# Altium schematic sheet Cray1-2.SchDoc
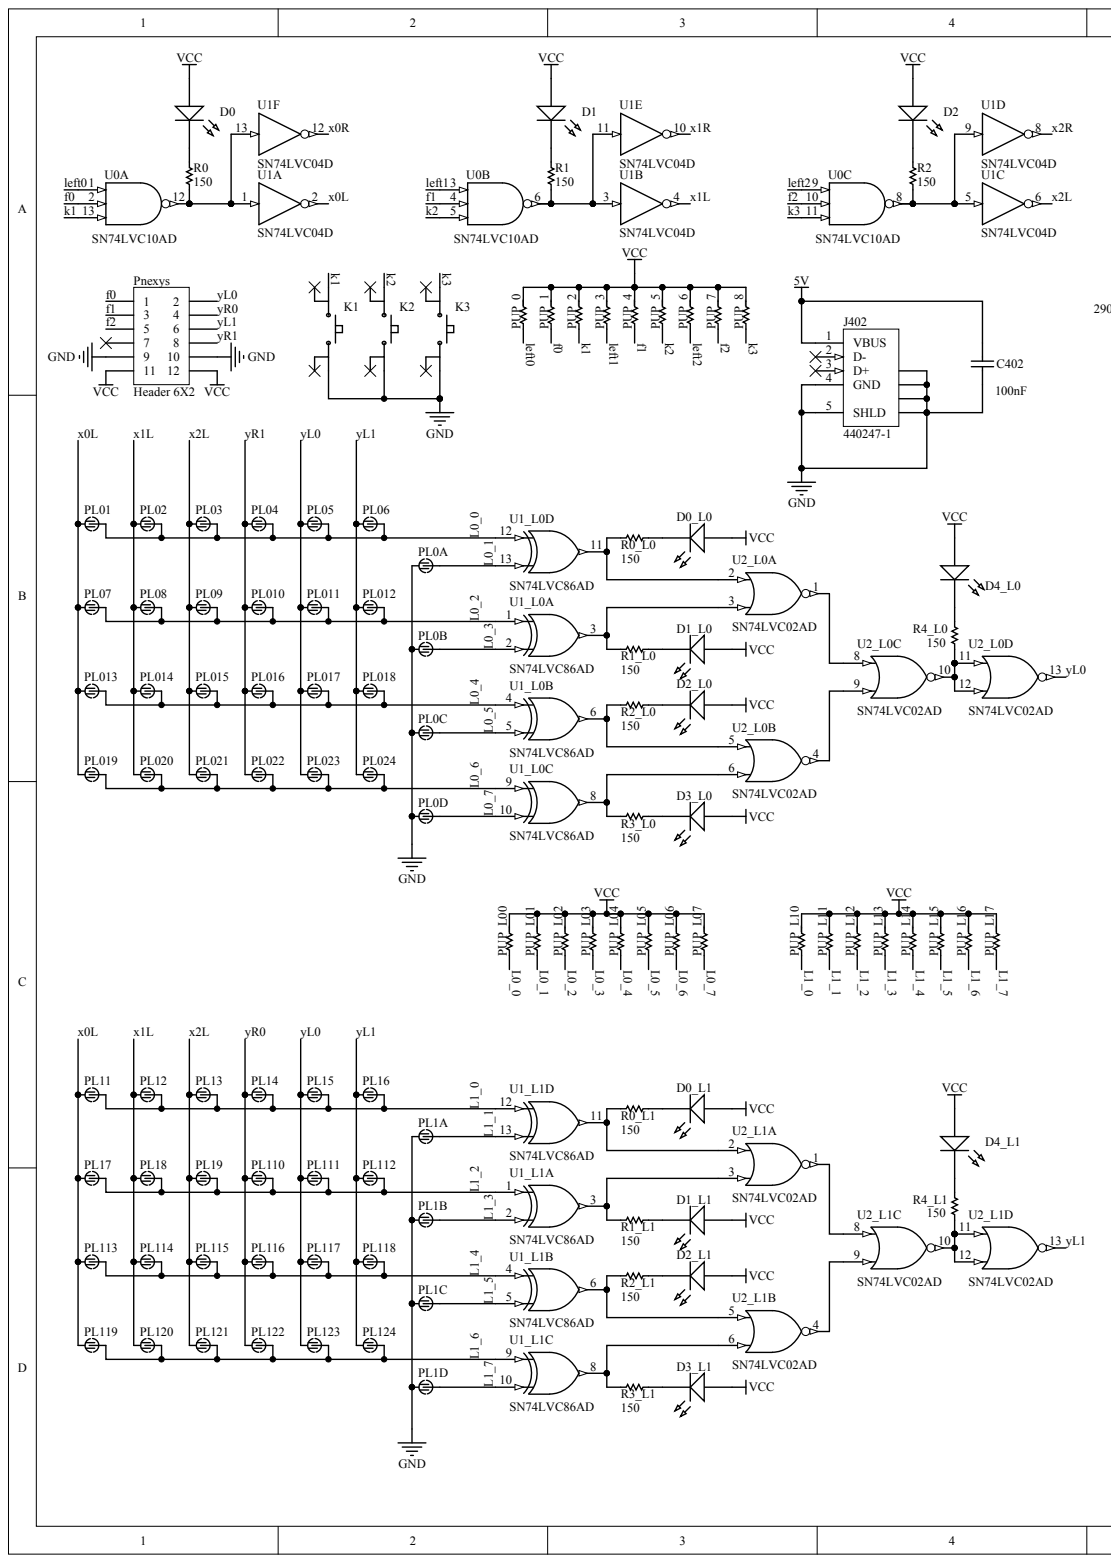
[diagram p0: Cray1-2.SchDoc, left]
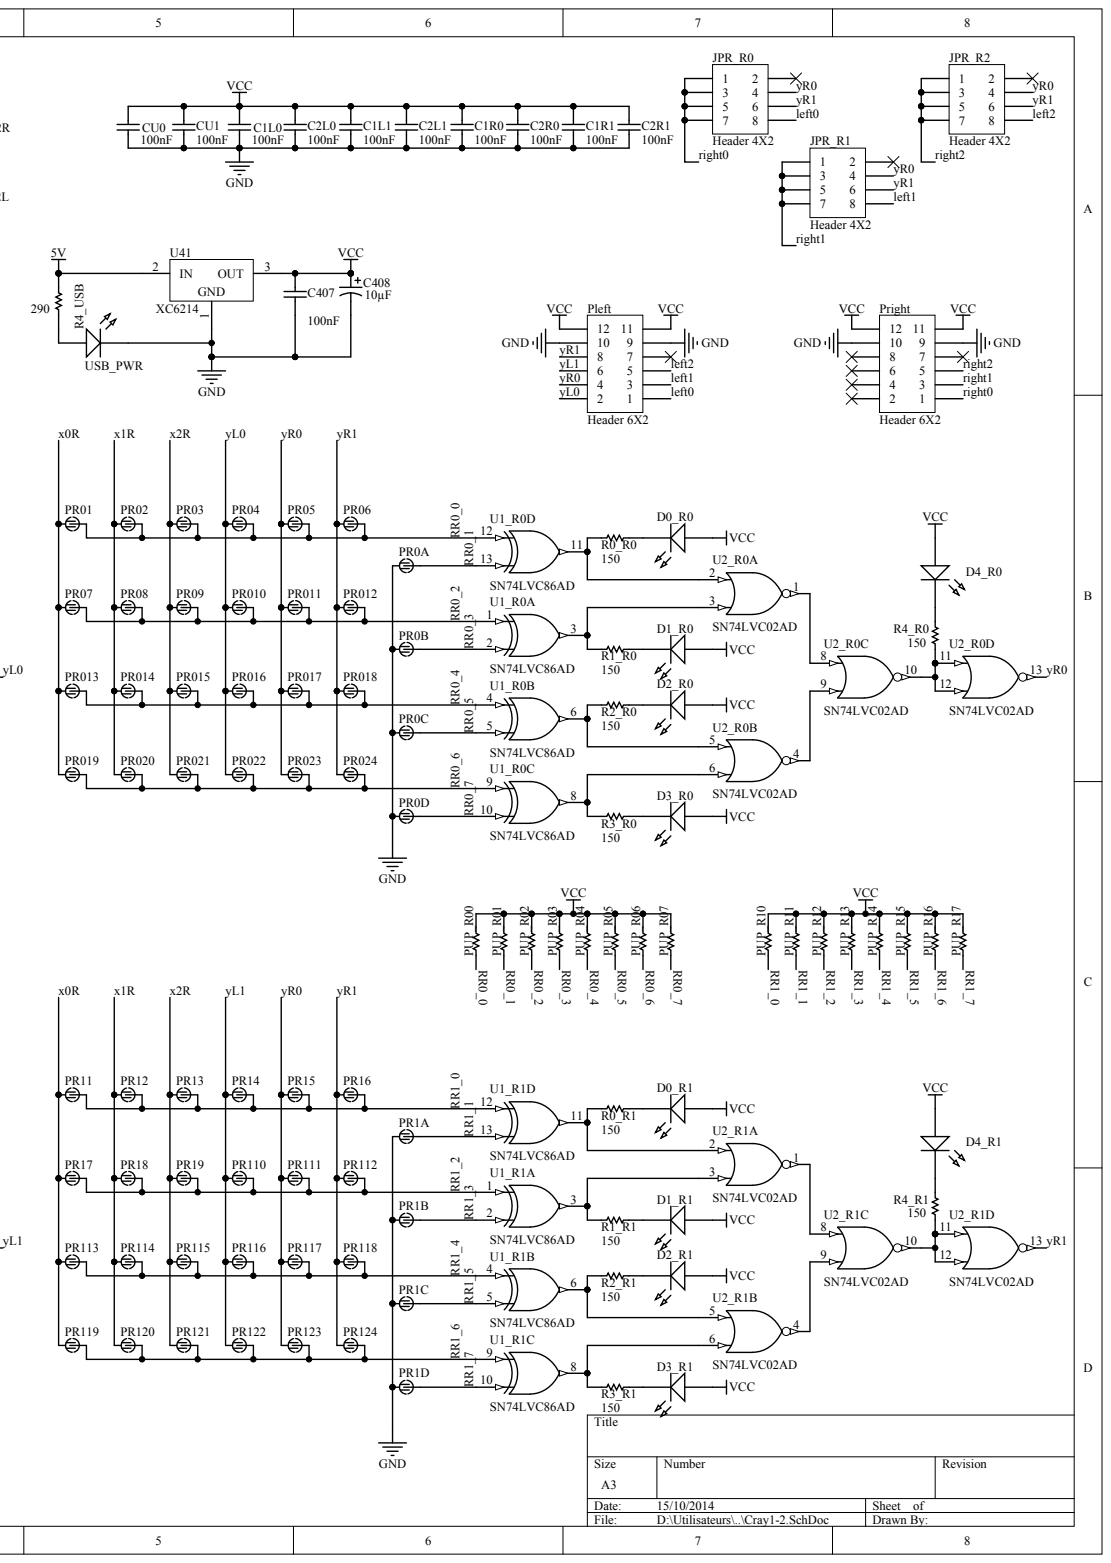
[diagram p1: Cray1-2.SchDoc, right]

## Parts
C1L0  value 100nF  (hidden comment: Cap Semi)
C1L1  value 100nF  (hidden comment: Cap Semi)
C1R0  value 100nF  (hidden comment: Cap Semi)
C1R1  value 100nF  (hidden comment: Cap Semi)
C2L0  value 100nF  (hidden comment: Cap Semi)
C2L1  value 100nF  (hidden comment: Cap Semi)
C2R0  value 100nF  (hidden comment: Cap Semi)
C2R1  value 100nF  (hidden comment: Cap Semi)
C402  value 100nF  (hidden comment: Cap Semi)
C407  value 100nF  (hidden comment: Cap Semi)
C408  value 10µF  (hidden comment: Cap Pol3)
CU0  value 100nF  (hidden comment: Cap Semi)
CU1  value 100nF  (hidden comment: Cap Semi)
D0  Led  (value not drawn)
D0_L0  Led  (value not drawn)
D0_L1  Led  (value not drawn)
D0_R0  Led  (value not drawn)
D0_R1  Led  (value not drawn)
D1  Led  (value not drawn)
D1_L0  Led  (value not drawn)
D1_L1  Led  (value not drawn)
D1_R0  Led  (value not drawn)
D1_R1  Led  (value not drawn)
D2  Led  (value not drawn)
D2_L0  Led  (value not drawn)
D2_L1  Led  (value not drawn)
D2_R0  Led  (value not drawn)
D2_R1  Led  (value not drawn)
D3_L0  Led  (value not drawn)
D3_L1  Led  (value not drawn)
D3_R0  Led  (value not drawn)
D3_R1  Led  (value not drawn)
D4_L0  Led  (value not drawn)
D4_L1  Led  (value not drawn)
D4_R0  Led  (value not drawn)
D4_R1  Led  (value not drawn)
J402  440247-1
JPR_R0  Header 4X2
JPR_R1  Header 4X2
JPR_R2  Header 4X2
K1  SPST MicroSwitch  (value not drawn)
K2  SPST MicroSwitch  (value not drawn)
K3  SPST MicroSwitch  (value not drawn)
PL0A  (no value)
PL0B  (no value)
PL0C  (no value)
PL0D  (no value)
PL01  (no value)
PL1A  (no value)
PL1B  (no value)
PL1C  (no value)
PL1D  (no value)
PL02  (no value)
PL03  (no value)
PL04  (no value)
PL05  (no value)
PL06  (no value)
PL07  (no value)
PL08  (no value)
PL09  (no value)
PL010  (no value)
PL011  (no value)
PL11  (no value)
PL012  (no value)
PL12  (no value)
PL013  (no value)
PL13  (no value)
PL014  (no value)
PL14  (no value)
PL015  (no value)
PL15  (no value)
PL016  (no value)
PL16  (no value)
PL017  (no value)
PL17  (no value)
PL018  (no value)
PL18  (no value)
PL019  (no value)
PL19  (no value)
PL020  (no value)
PL021  (no value)
PL022  (no value)
PL023  (no value)
PL024  (no value)
PL110  (no value)
PL111  (no value)
PL112  (no value)
PL113  (no value)
PL114  (no value)
PL115  (no value)
PL116  (no value)
PL117  (no value)
PL118  (no value)
PL119  (no value)
PL120  (no value)
PL121  (no value)
PL122  (no value)
PL123  (no value)
PL124  (no value)
Pleft  Header 6X2
Pnexys  Header 6X2
PR0A  (no value)
PR0B  (no value)
PR0C  (no value)
PR0D  (no value)
PR01  (no value)
PR1A  (no value)
PR1B  (no value)
PR1C  (no value)
PR1D  (no value)
PR02  (no value)
PR03  (no value)
PR04  (no value)
PR05  (no value)
PR06  (no value)
PR07  (no value)
PR08  (no value)
PR09  (no value)
PR010  (no value)
PR011  (no value)
PR11  (no value)
PR012  (no value)
PR12  (no value)
PR013  (no value)
PR13  (no value)
PR014  (no value)
PR14  (no value)
PR015  (no value)
PR15  (no value)
PR016  (no value)
PR16  (no value)
PR017  (no value)
PR17  (no value)
PR018  (no value)
PR18  (no value)
PR019  (no value)
PR19  (no value)
PR020  (no value)
PR021  (no value)
PR022  (no value)
PR023  (no value)
PR024  (no value)
PR110  (no value)
PR111  (no value)
PR112  (no value)
PR113  (no value)
PR114  (no value)
PR115  (no value)
PR116  (no value)
PR117  (no value)
PR118  (no value)
PR119  (no value)
PR120  (no value)
PR121  (no value)
PR122  (no value)
PR123  (no value)
PR124  (no value)
Pright  Header 6X2
PUP_0  Res Semi  (value not drawn)
PUP_1  Res Semi  (value not drawn)
PUP_2  Res Semi  (value not drawn)
PUP_3  Res Semi  (value not drawn)
PUP_4  Res Semi  (value not drawn)
PUP_5  Res Semi  (value not drawn)
PUP_6  Res Semi  (value not drawn)
PUP_7  Res Semi  (value not drawn)
PUP_8  Res Semi  (value not drawn)
PUP_L00  Res Semi  (value not drawn)
PUP_L01  Res Semi  (value not drawn)
PUP_L02  Res Semi  (value not drawn)
PUP_L03  Res Semi  (value not drawn)
PUP_L04  Res Semi  (value not drawn)
PUP_L05  Res Semi  (value not drawn)
PUP_L06  Res Semi  (value not drawn)
PUP_L07  Res Semi  (value not drawn)
PUP_L10  Res Semi  (value not drawn)
PUP_L11  Res Semi  (value not drawn)
PUP_L12  Res Semi  (value not drawn)
PUP_L13  Res Semi  (value not drawn)
PUP_L14  Res Semi  (value not drawn)
PUP_L15  Res Semi  (value not drawn)
PUP_L16  Res Semi  (value not drawn)
PUP_L17  Res Semi  (value not drawn)
PUP_R00  Res Semi  (value not drawn)
PUP_R01  Res Semi  (value not drawn)
PUP_R02  Res Semi  (value not drawn)
PUP_R03  Res Semi  (value not drawn)
PUP_R04  Res Semi  (value not drawn)
PUP_R05  Res Semi  (value not drawn)
PUP_R06  Res Semi  (value not drawn)
PUP_R07  Res Semi  (value not drawn)
PUP_R10  Res Semi  (value not drawn)
PUP_R11  Res Semi  (value not drawn)
PUP_R12  Res Semi  (value not drawn)
PUP_R13  Res Semi  (value not drawn)
PUP_R14  Res Semi  (value not drawn)
PUP_R15  Res Semi  (value not drawn)
PUP_R16  Res Semi  (value not drawn)
PUP_R17  Res Semi  (value not drawn)
R0  value 150  (hidden comment: Res Semi)
R0_L0  value 150  (hidden comment: Res Semi)
R0_L1  value 150  (hidden comment: Res Semi)
R0_R0  value 150  (hidden comment: Res Semi)
R0_R1  value 150  (hidden comment: Res Semi)
R1  value 150  (hidden comment: Res Semi)
R1_L0  value 150  (hidden comment: Res Semi)
R1_L1  value 150  (hidden comment: Res Semi)
R1_R0  value 150  (hidden comment: Res Semi)
R1_R1  value 150  (hidden comment: Res Semi)
R2  value 150  (hidden comment: Res Semi)
R2_L0  value 150  (hidden comment: Res Semi)
R2_L1  value 150  (hidden comment: Res Semi)
R2_R0  value 150  (hidden comment: Res Semi)
R2_R1  value 150  (hidden comment: Res Semi)
R3_L0  value 150  (hidden comment: Res Semi)
R3_L1  value 150  (hidden comment: Res Semi)
R3_R0  value 150  (hidden comment: Res Semi)
R3_R1  value 150  (hidden comment: Res Semi)
R4_L0  value 150  (hidden comment: Res Semi)
R4_L1  value 150  (hidden comment: Res Semi)
R4_R0  value 150  (hidden comment: Res Semi)
R4_R1  value 150  (hidden comment: Res Semi)
R4_USB  value 290  (hidden comment: Res Semi)
U0  SN74LVC10AD  (parts A,B,C)
U1  SN74LVC04D  (parts A,B,C,D,E,F)
U1_L0  SN74LVC86AD  (parts A,B,C,D)
U1_L1  SN74LVC86AD  (parts A,B,C,D)
U1_R0  SN74LVC86AD  (parts A,B,C,D)
U1_R1  SN74LVC86AD  (parts A,B,C,D)
U2_L0  SN74LVC02AD  (parts A,B,C,D)
U2_L1  SN74LVC02AD  (parts A,B,C,D)
U2_R0  SN74LVC02AD  (parts A,B,C,D)
U2_R1  SN74LVC02AD  (parts A,B,C,D)
U41  XC6214
USB_PWR  Led  (value not drawn)

## Nets
5V: C402.2 J402.1(VBUS) R4_USB.1 U41.2
GND: C1L0.1 C1L1.1 C1R0.1 C1R1.1 C2L0.1 C2L1.1 C2R0.1 C2R1.1 C402.1 C407.1 C408.2 CU0.1 CU1.1 J402.4(GND) J402.5(SHLD) J402.6 J402.7 J402.8 J402.9 K1.3 K2.3 K3.3 PL0A.1 PL0B.1 PL0C.1 PL0D.1 PL1A.1 PL1B.1 PL1C.1 PL1D.1 Pleft.9 Pleft.10 Pnexys.9 Pnexys.10 PR0A.1 PR0B.1 PR0C.1 PR0D.1 PR1A.1 PR1B.1 PR1C.1 PR1D.1 Pright.9 Pright.10 U0.7 U1.7 U1_L0.7 U1_L1.7 U1_R0.7 U1_R1.7 U2_L0.7 U2_L1.7 U2_R0.7 U2_R1.7 U41.1 USB_PWR.K
VCC: C1L0.2 C1L1.2 C1R0.2 C1R1.2 C2L0.2 C2L1.2 C2R0.2 C2R1.2 C407.2 C408.1 CU0.2 CU1.2 D0.A D0_L0.A D0_L1.A D0_R0.A D0_R1.A D1.A D1_L0.A D1_L1.A D1_R0.A D1_R1.A D2.A D2_L0.A D2_L1.A D2_R0.A D2_R1.A D3_L0.A D3_L1.A D3_R0.A D3_R1.A D4_L0.A D4_L1.A D4_R0.A D4_R1.A Pleft.11 Pleft.12 Pnexys.11 Pnexys.12 Pright.11 Pright.12 PUP_0.1 PUP_1.1 PUP_2.1 PUP_3.1 PUP_4.1 PUP_5.1 PUP_6.1 PUP_7.1 PUP_8.1 PUP_L00.1 PUP_L01.1 PUP_L02.1 PUP_L03.1 PUP_L04.1 PUP_L05.1 PUP_L06.1 PUP_L07.1 PUP_L10.1 PUP_L11.1 PUP_L12.1 PUP_L13.1 PUP_L14.1 PUP_L15.1 PUP_L16.1 PUP_L17.1 PUP_R00.1 PUP_R01.1 PUP_R02.1 PUP_R03.1 PUP_R04.1 PUP_R05.1 PUP_R06.1 PUP_R07.1 PUP_R10.1 PUP_R11.1 PUP_R12.1 PUP_R13.1 PUP_R14.1 PUP_R15.1 PUP_R16.1 PUP_R17.1 U0.14 U1.14 U1_L0.14 U1_L1.14 U1_R0.14 U1_R1.14 U2_L0.14 U2_L1.14 U2_R0.14 U2_R1.14 U41.3
F0: Pnexys.1 PUP_1.2 U0.2
F1: Pnexys.3 PUP_4.2 U0.4
F2: Pnexys.5 PUP_7.2 U0.10
K1: K1.1 PUP_2.2 U0.13
K2: K2.1 PUP_5.2 U0.5
K3: K3.1 PUP_8.2 U0.11
L0_0: PL01.0 PL02.0 PL03.0 PL04.0 PL05.0 PL06.0 PUP_L00.2 U1_L0.12
L0_1: PL0A.0 PUP_L01.2 U1_L0.13
L0_2: PL07.0 PL08.0 PL09.0 PL010.0 PL011.0 PL012.0 PUP_L02.2 U1_L0.1
L0_3: PL0B.0 PUP_L03.2 U1_L0.2
L0_4: PL013.0 PL014.0 PL015.0 PL016.0 PL017.0 PL018.0 PUP_L04.2 U1_L0.4
L0_5: PL0C.0 PUP_L05.2 U1_L0.5
L0_6: PL019.0 PL020.0 PL021.0 PL022.0 PL023.0 PL024.0 PUP_L06.2 U1_L0.9
L0_7: PL0D.0 PUP_L07.2 U1_L0.10
L1_0: PL11.0 PL12.0 PL13.0 PL14.0 PL15.0 PL16.0 PUP_L10.2 U1_L1.12
L1_1: PL1A.0 PUP_L11.2 U1_L1.13
L1_2: PL17.0 PL18.0 PL19.0 PL110.0 PL111.0 PL112.0 PUP_L12.2 U1_L1.1
L1_3: PL1B.0 PUP_L13.2 U1_L1.2
L1_4: PL113.0 PL114.0 PL115.0 PL116.0 PL117.0 PL118.0 PUP_L14.2 U1_L1.4
L1_5: PL1C.0 PUP_L15.2 U1_L1.5
L1_6: PL119.0 PL120.0 PL121.0 PL122.0 PL123.0 PL124.0 PUP_L16.2 U1_L1.9
L1_7: PL1D.0 PUP_L17.2 U1_L1.10
LEFT0: JPR_R0.8 Pleft.1 PUP_0.2 U0.1
LEFT1: JPR_R1.8 Pleft.3 PUP_3.2 U0.3
LEFT2: JPR_R2.8 Pleft.5 PUP_6.2 U0.9
RIGHT0: JPR_R0.1 JPR_R0.3 JPR_R0.5 JPR_R0.7 Pright.1
RIGHT1: JPR_R1.1 JPR_R1.3 JPR_R1.5 JPR_R1.7 Pright.3
RIGHT2: JPR_R2.1 JPR_R2.3 JPR_R2.5 JPR_R2.7 Pright.5
RR0_0: PR01.0 PR02.0 PR03.0 PR04.0 PR05.0 PR06.0 PUP_R00.2 U1_R0.12
RR0_1: PR0A.0 PUP_R01.2 U1_R0.13
RR0_2: PR07.0 PR08.0 PR09.0 PR010.0 PR011.0 PR012.0 PUP_R02.2 U1_R0.1
RR0_3: PR0B.0 PUP_R03.2 U1_R0.2
RR0_4: PR013.0 PR014.0 PR015.0 PR016.0 PR017.0 PR018.0 PUP_R04.2 U1_R0.4
RR0_5: PR0C.0 PUP_R05.2 U1_R0.5
RR0_6: PR019.0 PR020.0 PR021.0 PR022.0 PR023.0 PR024.0 PUP_R06.2 U1_R0.9
RR0_7: PR0D.0 PUP_R07.2 U1_R0.10
RR1_0: PR11.0 PR12.0 PR13.0 PR14.0 PR15.0 PR16.0 PUP_R10.2 U1_R1.12
RR1_1: PR1A.0 PUP_R11.2 U1_R1.13
RR1_2: PR17.0 PR18.0 PR19.0 PR110.0 PR111.0 PR112.0 PUP_R12.2 U1_R1.1
RR1_3: PR1B.0 PUP_R13.2 U1_R1.2
RR1_4: PR113.0 PR114.0 PR115.0 PR116.0 PR117.0 PR118.0 PUP_R14.2 U1_R1.4
RR1_5: PR1C.0 PUP_R15.2 U1_R1.5
RR1_6: PR119.0 PR120.0 PR121.0 PR122.0 PR123.0 PR124.0 PUP_R16.2 U1_R1.9
RR1_7: PR1D.0 PUP_R17.2 U1_R1.10
X0L: PL01.1 PL07.1 PL11.1 PL013.1 PL17.1 PL019.1 PL113.1 PL119.1 U1.2
X0R: PR01.1 PR07.1 PR11.1 PR013.1 PR17.1 PR019.1 PR113.1 PR119.1 U1.12
X1L: PL02.1 PL08.1 PL12.1 PL014.1 PL18.1 PL020.1 PL114.1 PL120.1 U1.4
X1R: PR02.1 PR08.1 PR12.1 PR014.1 PR18.1 PR020.1 PR114.1 PR120.1 U1.10
X2L: PL03.1 PL09.1 PL13.1 PL015.1 PL19.1 PL021.1 PL115.1 PL121.1 U1.6
X2R: PR03.1 PR09.1 PR13.1 PR015.1 PR19.1 PR021.1 PR115.1 PR121.1 U1.8
YL0: PL05.1 PL011.1 PL15.1 PL017.1 PL023.1 PL111.1 PL117.1 PL123.1 Pleft.2 Pnexys.2 PR04.1 PR010.1 PR016.1 PR022.1 U2_L0.13
YL1: PL06.1 PL012.1 PL16.1 PL018.1 PL024.1 PL112.1 PL118.1 PL124.1 Pleft.6 Pnexys.6 PR14.1 PR110.1 PR116.1 PR122.1 U2_L1.13
YR0: JPR_R0.4 JPR_R1.4 JPR_R2.4 PL14.1 PL110.1 PL116.1 PL122.1 Pleft.4 Pnexys.4 PR05.1 PR011.1 PR15.1 PR017.1 PR023.1 PR111.1 PR117.1 PR123.1 U2_R0.13
YR1: JPR_R0.6 JPR_R1.6 JPR_R2.6 PL04.1 PL010.1 PL016.1 PL022.1 Pleft.8 Pnexys.8 PR06.1 PR012.1 PR16.1 PR018.1 PR024.1 PR112.1 PR118.1 PR124.1 U2_R1.13
NetD0_K: D0.K R0.1
NetD0_L0_K: D0_L0.K R0_L0.1
NetD0_L1_K: D0_L1.K R0_L1.1
NetD0_R0_K: D0_R0.K R0_R0.1
NetD0_R1_K: D0_R1.K R0_R1.1
NetD1_K: D1.K R1.1
NetD1_L0_K: D1_L0.K R1_L0.1
NetD1_L1_K: D1_L1.K R1_L1.1
NetD1_R0_K: D1_R0.K R1_R0.1
NetD1_R1_K: D1_R1.K R1_R1.1
NetD2_K: D2.K R2.1
NetD2_L0_K: D2_L0.K R2_L0.1
NetD2_L1_K: D2_L1.K R2_L1.1
NetD2_R0_K: D2_R0.K R2_R0.1
NetD2_R1_K: D2_R1.K R2_R1.1
NetD3_L0_K: D3_L0.K R3_L0.1
NetD3_L1_K: D3_L1.K R3_L1.1
NetD3_R0_K: D3_R0.K R3_R0.1
NetD3_R1_K: D3_R1.K R3_R1.1
NetD4_L0_K: D4_L0.K R4_L0.1
NetD4_L1_K: D4_L1.K R4_L1.1
NetD4_R0_K: D4_R0.K R4_R0.1
NetD4_R1_K: D4_R1.K R4_R1.1
NetR0_2: R0.2 U0.12 U1.1 U1.13
NetR0_L0_2: R0_L0.2 U1_L0.11 U2_L0.2
NetR0_L1_2: R0_L1.2 U1_L1.11 U2_L1.2
NetR0_R0_2: R0_R0.2 U1_R0.11 U2_R0.2
NetR0_R1_2: R0_R1.2 U1_R1.11 U2_R1.2
NetR1_2: R1.2 U0.6 U1.3 U1.11
NetR1_L0_2: R1_L0.2 U1_L0.3 U2_L0.3
NetR1_L1_2: R1_L1.2 U1_L1.3 U2_L1.3
NetR1_R0_2: R1_R0.2 U1_R0.3 U2_R0.3
NetR1_R1_2: R1_R1.2 U1_R1.3 U2_R1.3
NetR2_2: R2.2 U0.8 U1.5 U1.9
NetR2_L0_2: R2_L0.2 U1_L0.6 U2_L0.5
NetR2_L1_2: R2_L1.2 U1_L1.6 U2_L1.5
NetR2_R0_2: R2_R0.2 U1_R0.6 U2_R0.5
NetR2_R1_2: R2_R1.2 U1_R1.6 U2_R1.5
NetR3_L0_2: R3_L0.2 U1_L0.8 U2_L0.6
NetR3_L1_2: R3_L1.2 U1_L1.8 U2_L1.6
NetR3_R0_2: R3_R0.2 U1_R0.8 U2_R0.6
NetR3_R1_2: R3_R1.2 U1_R1.8 U2_R1.6
NetR4_L0_2: R4_L0.2 U2_L0.10 U2_L0.11 U2_L0.12
NetR4_L1_2: R4_L1.2 U2_L1.10 U2_L1.11 U2_L1.12
NetR4_R0_2: R4_R0.2 U2_R0.10 U2_R0.11 U2_R0.12
NetR4_R1_2: R4_R1.2 U2_R1.10 U2_R1.11 U2_R1.12
NetR4_USB_2: R4_USB.2 USB_PWR.A
NetU2_L0_1: U2_L0.1 U2_L0.8
NetU2_L0_4: U2_L0.4 U2_L0.9
NetU2_L1_1: U2_L1.1 U2_L1.8
NetU2_L1_4: U2_L1.4 U2_L1.9
NetU2_R0_1: U2_R0.1 U2_R0.8
NetU2_R0_4: U2_R0.4 U2_R0.9
NetU2_R1_1: U2_R1.1 U2_R1.8
NetU2_R1_4: U2_R1.4 U2_R1.9

Not connected: J402 2(D-) 3(D+); JPR_R0 2; JPR_R1 2; JPR_R2 2; K1 2 4; K2 2 4; K3 2 4; Pleft 7; Pnexys 7; Pright 2 4 6 7 8

## Library data of the parts
J402 = (no symbol name): ContactMaterial: Copper Alloy; ContactPlating: Gold (30); PCB_Layout: Complies with manufacturer's recommendation.; DrillSize: Corresponds to nominal value.; PanelGround: Without; Published: 15-Jul-2004; DatasheetDocument: 27-Jun-2003; PackageDocument: 27-Jun-2003; PackageReference: 440247; HousingMaterial: Thermoplastic; ComponentLink1Description: Manufacturer Link; ComponentLink1URL: http://www.tycoelectronics.com/; ComponentLink2Description: Packaging Specification; ComponentLink2URL: http://ecommas.tycoelectronics.com/commerce/DocumentDelivery/DDEController?Action=showdoc&DocId=Customer+Drawing%7F440247%7FD%7Fpdf%7FEnglish%7FC_440247_D.pdf; Publisher: Altium Limited
PUP_0-PUP_8 PUP_L00 PUP_L01 PUP_L02 PUP_L03 PUP_L04 PUP_L05 PUP_L06 PUP_L07 PUP_L10-PUP_L17 PUP_R00 PUP_R01 PUP_R02 PUP_R03 PUP_R04 PUP_R05 PUP_R06 PUP_R07 PUP_R10-PUP_R17 = (no symbol name): Value: 1K; Published: 8-Jun-2000; LatestRevisionDate: 17-Jul-2002; LatestRevisionNote: Re-released for DXP Platform.; PackageReference: AXIAL-0.5; PackageDescription: Axial Device, Thru-Hole; 2 Leads; 0.5 in Pin Spacing; Publisher: Altium Limited
C1L0 C1L1 C1R0 C1R1 C2L0 C2L1 C2R0 C2R1 C402 C407 C408 CU0 CU1 D0 D0_L0 D0_L1 D0_R0 D0_R1 D1 D1_L0 D1_L1 D1_R0 D1_R1 D2 D2_L0 D2_L1 D2_R0 D2_R1 D3_L0 D3_L1 D3_R0 D3_R1 D4_L0 D4_L1 D4_R0 D4_R1 JPR_R0-JPR_R2 K1-K3 PL0A PL0B PL0C PL0D PL01 PL1A PL1B PL1C PL1D PL02 PL03 PL04 PL05 PL06 PL07 PL08 PL09 PL010 PL011 PL11 PL012 PL12 PL013 PL13 PL014 PL14 PL015 PL15 PL016 PL16 PL017 PL17 PL018 PL18 PL019 PL19 PL020 PL021 PL022 PL023 PL024 PL110-PL124 Pleft Pnexys PR0A PR0B PR0C PR0D PR01 PR1A PR1B PR1C PR1D PR02 PR03 PR04 PR05 PR06 PR07 PR08 PR09 PR010 PR011 PR11 PR012 PR12 PR013 PR13 PR014 PR14 PR015 PR15 PR016 PR16 PR017 PR17 PR018 PR18 PR019 PR19 PR020 PR021 PR022 PR023 PR024 PR110-PR124 Pright R0 R0_L0 R0_L1 R0_R0 R0_R1 R1 R1_L0 R1_L1 R1_R0 R1_R1 R2 R2_L0 R2_L1 R2_R0 R2_R1 R3_L0 R3_L1 R3_R0 R3_R1 R4_L0 R4_L1 R4_R0 R4_R1 R4_USB U0 U1 U1_L0 U1_L1 U1_R0 U1_R1 U2_L0 U2_L1 U2_R0 U2_R1 U41 USB_PWR = (no symbol name)
  C1L0 C1L1 C1R0 C1R1 C2L0 C2L1 C2R0 C2R1 C402 C407 CU0 CU1: Published: 8-Jun-2000; LatestRevisionDate: 29-May-2009; LatestRevisionNote: IPC-7351 Footprint Added.; PackageReference: C1206; PackageDescription: Chip Capacitor
  C408: Published: 8-Jun-2000; LatestRevisionDate: 29-May-2009; LatestRevisionNote: IPC-7351 Footprint Added.; PackageReference: C0805; PackageDescription: Chip Capacitor
  JPR_R0-JPR_R2 Pleft Pnexys Pright: LatestRevisionDate: 17-Jul-2002; LatestRevisionNote: Re-released for DXP Platform.
  R0 R0_L0 R0_L1 R0_R0 R0_R1 R1 R1_L0 R1_L1 R1_R0 R1_R1 R2 R2_L0 R2_L1 R2_R0 R2_R1 R3_L0 R3_L1 R3_R0 R3_R1 R4_L0 R4_L1 R4_R0 R4_R1 R4_USB: Published: 8-Jun-2000; LatestRevisionDate: 17-Jul-2002; LatestRevisionNote: Re-released for DXP Platform.; PackageReference: AXIAL-0.5; PackageDescription: Axial Device, Thru-Hole; 2 Leads; 0.5 in Pin Spacing
  U0: Published: 8-Jun-2000; LatestRevisionDate: 13-Apr-2006; LatestRevisionNote: IPC-7351 Footprint Added.; DatasheetVersion: Oct-1998; PackageVersion: Jan-1998; PackageReference: D014; PackageDescription: 14-Pin Small Outline Integrated Circuit 1.27 mm Pitch; Code_IPC: SOIC127P600-14; ComponentLink1Description: Manufacturer Link; ComponentLink1URL: http://www.ti.com/; ComponentLink2Description: Datasheet; ComponentLink2URL: http://www-s.ti.com/sc/ds/sn74lvc10a.pdf
  U1: Published: 8-Jun-2000; LatestRevisionDate: 13-Apr-2006; LatestRevisionNote: IPC-7351 Footprint Added.; DatasheetVersion: Jul-1995; PackageVersion: Jan-1998; PackageReference: D014; PackageDescription: 14-Pin Small Outline Integrated Circuit 1.27 mm Pitch; Code_IPC: SOIC127P600-14; ComponentLink1Description: Manufacturer Link; ComponentLink1URL: http://www.ti.com/
  U1_L0 U1_L1 U1_R0 U1_R1: Published: 8-Jun-2000; LatestRevisionDate: 13-Apr-2006; LatestRevisionNote: IPC-7351 Footprint Added.; DatasheetVersion: Oct-1998; PackageVersion: Jan-1998; PackageReference: D014; PackageDescription: 14-Pin Small Outline Integrated Circuit 1.27 mm Pitch; Code_IPC: SOIC127P600-14; ComponentLink1Description: Manufacturer Link; ComponentLink1URL: http://www.ti.com/; ComponentLink2Description: Datasheet; ComponentLink2URL: http://www-s.ti.com/sc/ds/SN54LVC86A.pdf
  U2_L0 U2_L1 U2_R0 U2_R1: Published: 8-Jun-2000; LatestRevisionDate: 13-Apr-2006; LatestRevisionNote: IPC-7351 Footprint Added.; DatasheetVersion: Oct-1998; PackageVersion: Jan-1998; PackageReference: D014; PackageDescription: 14-Pin Small Outline Integrated Circuit 1.27 mm Pitch; Code_IPC: SOIC127P600-14; ComponentLink1Description: Manufacturer Link; ComponentLink1URL: http://www.ti.com/; ComponentLink2Description: Datasheet; ComponentLink2URL: http://www-s.ti.com/sc/ds/SN54LVC02A.pdf
  C1L0 C1L1 C1R0 C1R1 C2L0 C2L1 C2R0 C2R1 C402 C407 C408 CU0 CU1 JPR_R0-JPR_R2 Pleft Pnexys Pright R0 R0_L0 R0_L1 R0_R0 R0_R1 R1 R1_L0 R1_L1 R1_R0 R1_R1 R2 R2_L0 R2_L1 R2_R0 R2_R1 R3_L0 R3_L1 R3_R0 R3_R1 R4_L0 R4_L1 R4_R0 R4_R1 R4_USB U0 U1 U1_L0 U1_L1 U1_R0 U1_R1 U2_L0 U2_L1 U2_R0 U2_R1: Publisher: Altium Limited
